# Altium schematic sheet W5500.SchDoc(W5500)
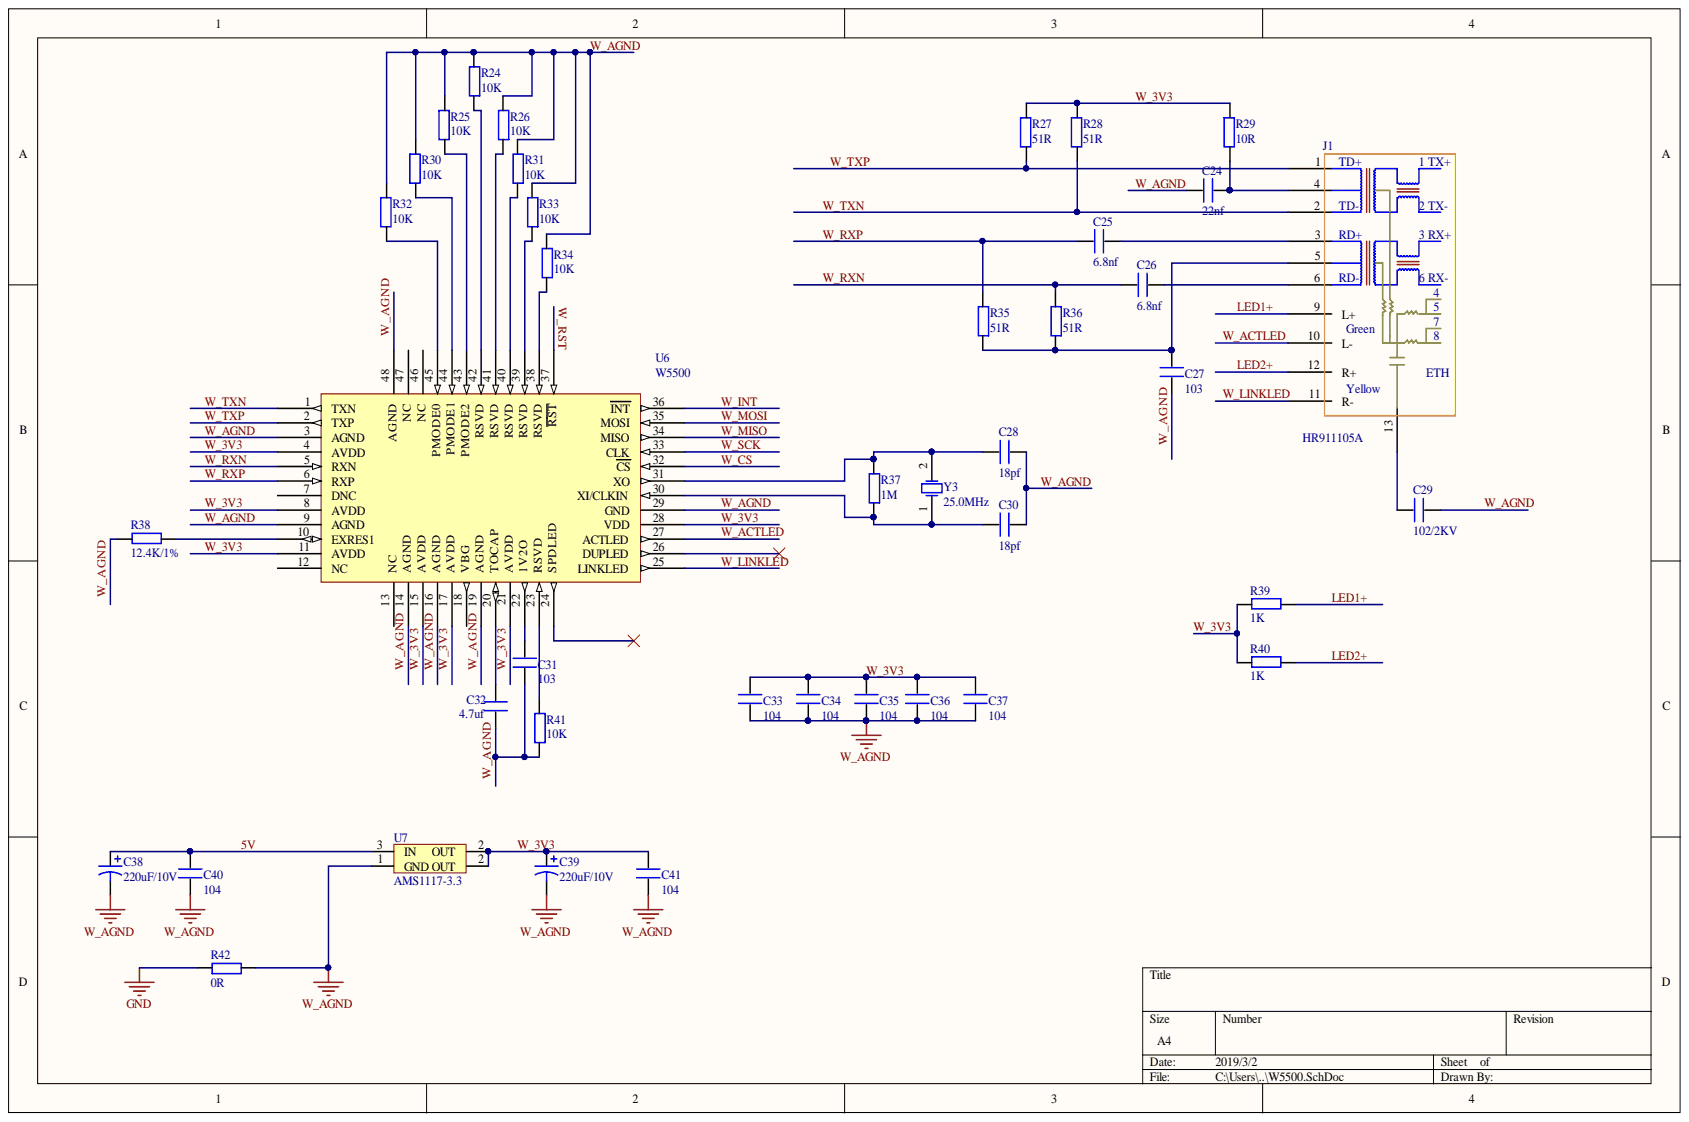
[diagram p0: W5500.SchDoc]

## Parts
C24  22nf  [0805]
C25  6.8nf  [0805]
C26  6.8nf  [0805]
C27  103  [0805]
C28  18pf  [0805]
C29  102/2KV  [0805]
C30  18pf  [0805]
C31  103  [0805]
C32  4.7uf  [0805]
C33  104  [0805]
C34  104  [0805]
C35  104  [0805]
C36  104  [0805]
C37  104  [0805]
C38  220uF/10V  [C5X8]
C39  220uF/10V  [C5X8]
C40  104  [0805]
C41  104  [0805]
J1  HR911105A  [HY951180A]
R24  10K  [0805]
R25  10K  [0805]
R26  10K  [0805]
R27  51R  [0805]
R28  51R  [0805]
R29  10R  [0805]
R30  10K  [0805]
R31  10K  [0805]
R32  10K  [0805]
R33  10K  [0805]
R34  10K  [0805]
R35  51R  [0805]
R36  51R  [0805]
R37  1M  [0805]
R38  12.4K/1%  [0805]
R39  1K  [0805]
R40  1K  [0805]
R41  10K  [0805]
R42  0R  [0805]
U6  W5500  [STM-LQFP48_M]
U7  AMS1117-3.3  [SOT-223]
Y3  25.0MHz  [49S-DIP]

## Nets
GND: R42.1
5V: C38.1 C40.1 U7.3
LED1+: J1.9(L+) R39.1
LED2+: J1.12(R+) R40.1
W_3V3: C33.1 C34.1 C35.1 C36.1 C37.1 C39.1 C41.1 R27.1 R28.1 R29.1 R39.2 R40.2 U6.4(AVDD) U6.8(AVDD) U6.11(AVDD) U6.15(AVDD) U6.17(AVDD) U6.21(AVDD) U6.28(VDD) U7.2
W_ACTLED: J1.10(L-) U6.27(ACTLED)
W_AGND: C24.1 C27.2 C28.1 C29.1 C30.1 C31.1 C32.1 C33.2 C34.2 C35.2 C36.2 C37.2 C38.2 C39.2 C40.2 C41.2 R24.2 R25.1 R26.2 R30.1 R31.2 R32.1 R33.2 R34.2 R38.2 R41.1 R42.2 U6.3(AGND) U6.9(AGND) U6.14(AGND) U6.16(AGND) U6.19(AGND) U6.29(GND) U6.48(AGND) U7.1
W_CS: U6.32(CS)
W_INT: U6.36(INT)
W_LINKLED: J1.11(R-) U6.25(LINKLED)
W_MISO: U6.34(MISO)
W_MOSI: U6.35(MOSI)
W_RST: U6.37(RST)
W_RXN: C26.1 R36.2 U6.5(RXN)
W_RXP: C25.1 R35.2 U6.6(RXP)
W_SCK: U6.33(CLK)
W_TXN: J1.2(TD-) R28.2 U6.1(TXN)
W_TXP: J1.1 R27.2 U6.2(TXP)
NetC24_2: C24.2 J1.4 R29.2
NetC25_2: C25.2 J1.3(RD+)
NetC26_2: C26.2 J1.6(RD-)
NetC27_1: C27.1 J1.5 R35.1 R36.1
NetC28_2: C28.2 R37.2 U6.31(XO) Y3.2
NetC29_2: C29.2 J1.13
NetC30_2: C30.2 R37.1 U6.30(XI/CLKIN) Y3.1
NetC31_2: C31.2 U6.22(1V2O)
NetC32_2: C32.2 U6.20(TOCAP)
NetR24_1: R24.1 U6.42(RSVD)
NetR25_2: R25.2 U6.43(PMODE2)
NetR26_1: R26.1 U6.41(RSVD)
NetR30_2: R30.2 U6.44(PMODE1)
NetR31_1: R31.1 U6.40(RSVD)
NetR32_2: R32.2 U6.45(PMODE0)
NetR33_1: R33.1 U6.39(RSVD)
NetR34_1: R34.1 U6.38(RSVD)
NetR38_1: R38.1 U6.10(EXRES1)
NetR41_2: R41.2 U6.23(RSVD)

Not connected: U6 7(DNC) 12(NC) 13(NC) 18(VBG) 24(SPDLED) 26(DUPLED) 46(NC) 47(NC)

## Library data of the parts
C24-C37 C40 C41 = C_1: Library Name: Explorer STM32F407封装库.IntLib; Component Kind: Standard
C38 C39 = Cap Pol1_1: Polarized Capacitor (Radial); Simulation: CAP; Signal Integrity: Cap Pol; Published: 8-Jun-2000; PackageReference: RB7.6-15; PackageDescription: Polarized Capacitor (Radial); 2 Leads; LatestRevisionNote: Re-released for DXP Platform.; LatestRevisionDate: 17-Jul-2002; Component Kind: Standard; Publisher: Altium Limited; Library Name: 除湿机控制.IntLib
R24-R42 = R: Component Kind: Standard; Library Name: 除湿机控制.IntLib
U7 = AMS1117: Component Kind: Standard; Library Name: 除湿机控制.IntLib
Y3 = XTAL: Crystal Oscillator; Simulation: XTAL; Published: 8-Jun-2000; LatestRevisionNote: Re-released for DXP Platform.; LatestRevisionDate: 17-Jul-2002; Component Kind: Standard; Publisher: Altium Limited; Library Name: 除湿机控制.IntLib
J1 = HR911105A: Library Name: Schlib1.Lib; Component Kind: Standard
U6 = W5500: Library Name: MySelf.SchLib; Component Kind: Standard
